# Altium schematic sheet zigbee开发板.SchDoc
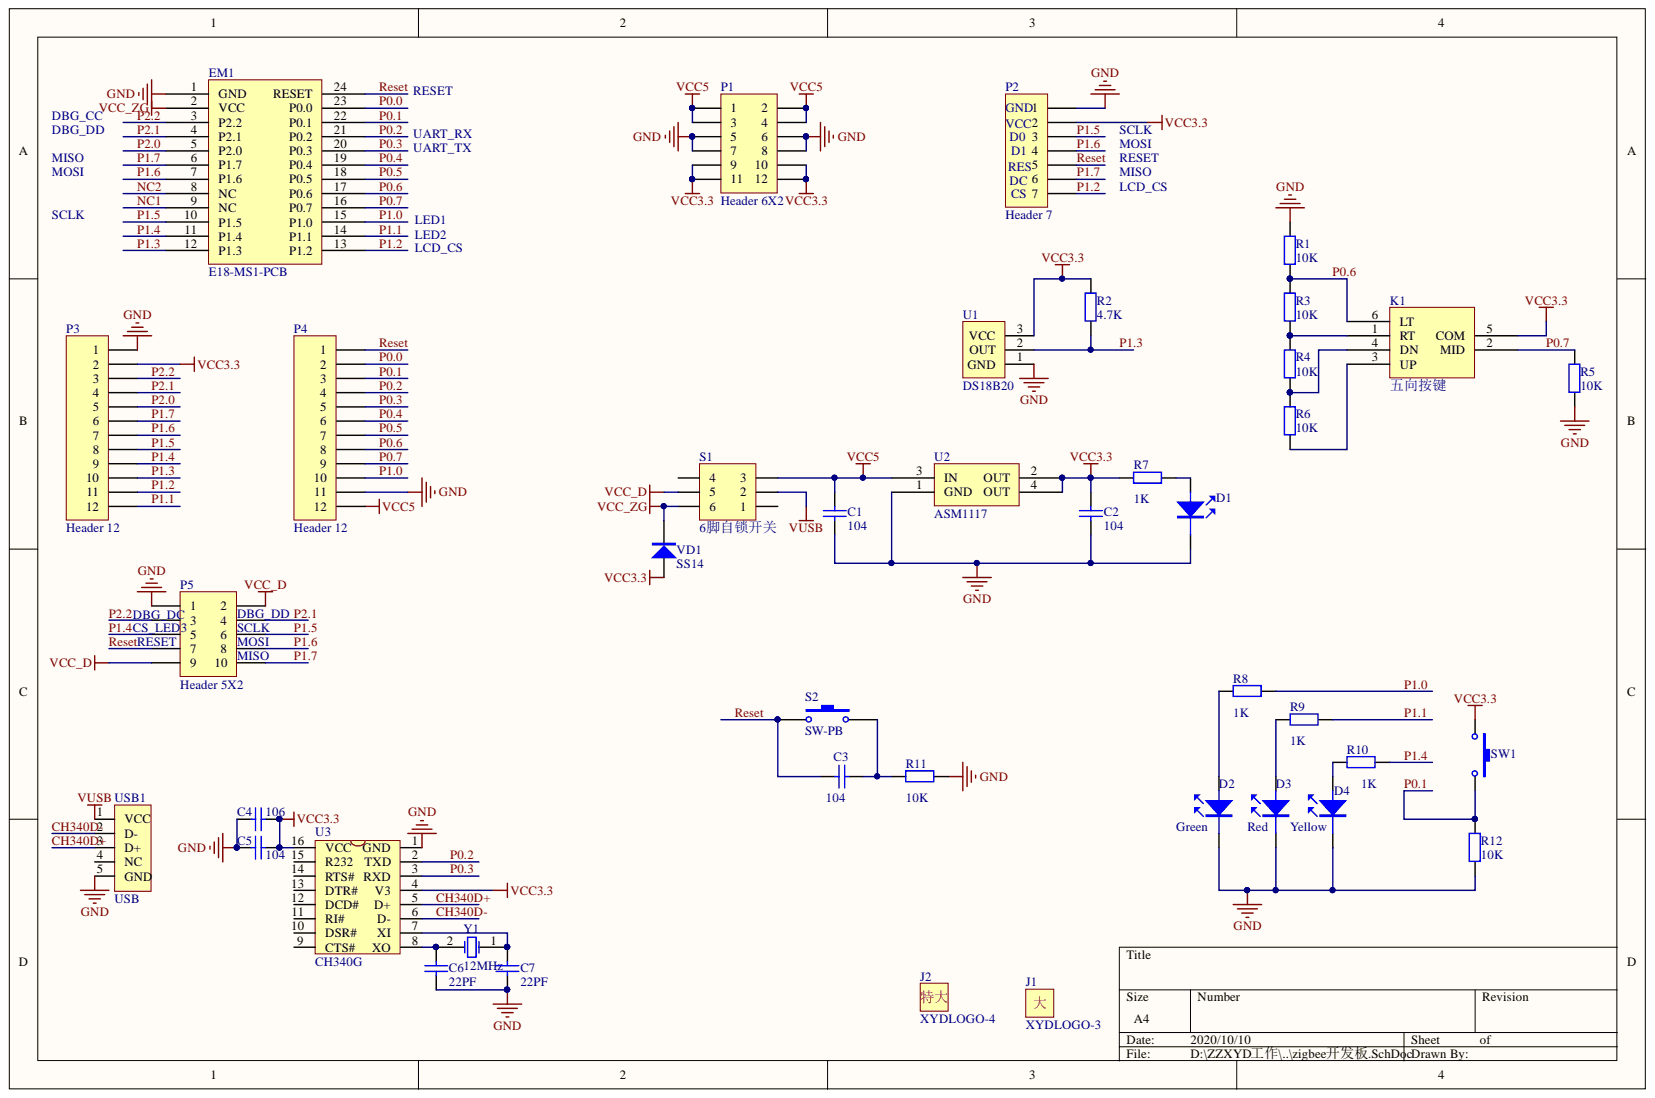
[diagram p0: zigbee开发板.SchDoc]

## Parts
C1  value 104  [C0603]  (hidden comment: Cap)
C2  value 104  [C0603]  (hidden comment: Cap)
C3  value 104  [C0603]  (hidden comment: Cap)
C4  value 106  [C0603]  (hidden comment: Cap)
C5  value 104  [C0603]  (hidden comment: Cap)
C6  value 22PF  [C0603]  (hidden comment: Cap)
C7  value 22PF  [C0603]  (hidden comment: Cap)
D1  Diode  [LED0805R]  (value not drawn)
D2  Diode  [LED0805R]  (value not drawn)
D3  Diode  [LED0805R]  (value not drawn)
D4  Diode  [LED0805R]  (value not drawn)
EM1  E18-MS1-PCB  [PE18-MS1-PCB]
J1  XYDLOGO-3  [XYDLOGO_3]
J2  XYDLOGO-4  [XYDLOGO_4]
K1  五向按键  [五向按键7*7]
P1  Header 6X2  [HDR2X6]
P2  Header 7  [HDR1X7]
P3  Header 12  [HDR2X6]
P4  Header 12  [HDR2X6]
P5  Header 5X2  [DEBUG]
R1  value 10K  [R0603]  (hidden comment: Res2)
R2  value 4.7K  [R0603]  (hidden comment: Res2)
R3  value 10K  [R0603]  (hidden comment: Res2)
R4  value 10K  [R0603]  (hidden comment: Res2)
R5  value 10K  [R0603]  (hidden comment: Res2)
R6  value 10K  [R0603]  (hidden comment: Res2)
R7  value 1K  [R0603]  (hidden comment: Res2)
R8  value 1K  [R0603]  (hidden comment: Res2)
R9  value 1K  [R0603]  (hidden comment: Res2)
R10  value 1K  [R0603]  (hidden comment: Res2)
R11  value 10K  [R0603]  (hidden comment: Res2)
R12  value 10K  [R0603]  (hidden comment: Res2)
S1  6脚自锁开关  [六脚自锁开关]
S2  SW-PB  [SW_PB2_B1]
SW1  SW-PB_1  [SW_PB2_B1]  (value not drawn)
U1  DS18B20  [DS18B20]
U2  ASM1117  [SOT-223]
U3  CH340G  [SOP16]
USB1  USB  [MINIUSB]
VD1  SS14  [SMA]
Y1  12MHz  [49S-DIP]

## Nets
GND: C1.1 C2.1 C4.1 C5.1 C6.1 C7.1 D1.2 D2.2 D3.2 D4.2 EM1.1(GND) P1.5 P1.6 P1.7 P1.8 P2.1 P3.1 P4.11 P5.1 R1.2 R5.1 R11.2 R12.1 U1.1 U2.1(GND) U3.1(GND) USB1.5(GND)
VCC3.3: C2.2 C4.2 C5.2 K1.5(COM) P1.9 P1.10 P1.11 P1.12 P2.2(VCC_2) P3.2 R2.2 R7.1 SW1.1 U1.3 U2.2(OUT) U2.4(OUT) U3.4(V3) U3.16(VCC) VD1.1
VCC5: C1.2 P1.1 P1.2 P1.3 P1.4 P4.12 S1.3 U2.3(IN)
VCC_D: P5.2 P5.9 S1.5
VCC_ZG: EM1.2(VCC) S1.6 VD1.2
VUSB: S1.2 USB1.1(VCC)
CH340D+: U3.5(D+) USB1.3(D+)
CH340D-: U3.6(D-) USB1.2(D-)
NC1: EM1.9(NC)
NC2: EM1.8(NC)
P0.0: EM1.23(P0.0) P4.2
P0.1: EM1.22(P0.1) P4.3 R12.2 SW1.2
P0.2: EM1.21(P0.2) P4.4 U3.2(TXD)
P0.3: EM1.20(P0.3) P4.5 U3.3(RXD)
P0.4: EM1.19(P0.4) P4.6
P0.5: EM1.18(P0.5) P4.7
P0.6: EM1.17(P0.6) K1.6(LT) P4.8 R1.1 R3.2
P0.7: EM1.16(P0.7) K1.2(MID) P4.9 R5.2
P1.0: EM1.15(P1.0) P4.10 R8.2
P1.1: EM1.14(P1.1) P3.12 R9.2
P1.2: EM1.13(P1.2) P2.7(CS) P3.11
P1.3: EM1.12(P1.3) P3.10 R2.1 U1.2
P1.4: EM1.11(P1.4) P3.9 P5.5 R10.2
P1.5: EM1.10(P1.5) P2.3 P3.8 P5.6
P1.6: EM1.7(P1.6) P2.4 P3.7 P5.8
P1.7: EM1.6(P1.7) P2.6(DC_6) P3.6 P5.10
P2.0: EM1.5(P2.0) P3.5
P2.1: EM1.4(P2.1) P3.4 P5.4
P2.2: EM1.3(P2.2) P3.3 P5.3
Reset: C3.1 EM1.24(RESET) P2.5(RES_5) P4.1 P5.7 S2.1
NetC3_2: C3.2 R11.1 S2.2
NetC6_2: C6.2 U3.8(XO) Y1.2
NetC7_2: C7.2 U3.7(XI) Y1.1
NetD1_1: D1.1 R7.2
NetD2_1: D2.1 R8.1
NetD3_1: D3.1 R9.1
NetD4_1: D4.1 R10.1
NetK1_1: K1.1(RT) R3.1 R4.2
NetK1_3: K1.3(UP) R6.1
NetK1_4: K1.4(DN) R4.1 R6.2

Not connected: S1 1 4; U3 9(CTS#) 10(DSR#) 11(RI#) 12(DCD#) 13(DTR#) 14(RTS#) 15(R232); USB1 4(NC)

## Library data of the parts
C1-C7 = Cap: Capacitor; Component Kind: Standard; LatestRevisionDate: 17-Jul-2002; LatestRevisionNote: Re-released for DXP Platform.; Library Name: Miscellaneous Devices.IntLib; PackageDescription: Radial Cap, Thru-Hole; 2 Leads; 0.3 in Pin Spacing; PackageReference: RAD-0.3; Published: 8-Jun-2000; Signal Integrity: Cap; Simulation: CAP; Publisher: Altium Limited
D1-D4 = ZT_LED: Parameter: BT322034; Component Kind: Standard; Library Name: 51最小系统.IntLib
EM1 = E18-MS1PA1-PCB: zegbee模块，带功放; Component Kind: Standard; Library Name: Basic.SchLib
P1 = Header 6X2: Header, 6-Pin, Dual row; Component Kind: Standard; LatestRevisionDate: 17-Jul-2002; LatestRevisionNote: Re-released for DXP Platform.; Library Name: Miscellaneous Connectors.IntLib; Signal Integrity: Connector; Publisher: Altium Limited
P2 = Header 7: Header, 7-Pin; Component Kind: Standard; LatestRevisionDate: 17-Jul-2002; LatestRevisionNote: Re-released for DXP Platform.; Library Name: Miscellaneous Connectors.IntLib; Signal Integrity: Connector; Publisher: Altium Limited
P3 P4 = Header 12: Header, 12-Pin; Component Kind: Standard; LatestRevisionDate: 17-Jul-2002; LatestRevisionNote: Re-released for DXP Platform.; Library Name: Miscellaneous Connectors.IntLib; Signal Integrity: Connector; Publisher: Altium Limited
P5 = Header 5X2: Header, 5-Pin, Dual row; Component Kind: Standard; LatestRevisionDate: 17-Jul-2002; LatestRevisionNote: Re-released for DXP Platform.; Library Name: Miscellaneous Connectors.IntLib; Signal Integrity: Connector; Publisher: Altium Limited
R1-R12 = Res2: Resistor; Component Kind: Standard; LatestRevisionDate: 17-Jul-2002; LatestRevisionNote: Re-released for DXP Platform.; Library Name: Miscellaneous Devices.IntLib; PackageDescription: Axial Device, Thru-Hole; 2 Leads; 0.4 in Pin Spacing; PackageReference: AXIAL-0.4; Published: 8-Jun-2000; Signal Integrity: Res; Simulation: RESISTOR; Publisher: Altium Limited
S2 = SW-PB: 微动开关; Component Kind: Standard; LatestRevisionDate: 17-Jul-2002; LatestRevisionNote: Re-released for DXP Platform.; PackageReference: SPST-2; Published: 24-Mar-1999; Library Name: Fszhang.IntLib; Publisher: Altium Limited
SW1 = SW-PB_1: Switch; Component Kind: Standard; LatestRevisionDate: 17-Jul-2002; LatestRevisionNote: Re-released for DXP Platform.; PackageDescription: Switch; 2 Leads; PackageReference: SPST-2; Published: 24-Mar-1999; Library Name: 51最小系统.IntLib; Publisher: Altium Limited
U2 = ASM1117: 3.3V稳压芯片; Component Kind: Standard; Library Name: fszhang.SchLib
U3 = CH340G: USB2UART; Component Kind: Standard; Library Name: WarShip V3 STM32103封装库.IntLib
USB1 = USB5: Component Kind: Standard; Library Name: WarShip V3 STM32103封装库.IntLib
VD1 = SS14: 肖特基二极管; 属性: 40V/1A; 版权归属: 源创客; BOM名称: 肖特基二极管; Component Kind: Standard; Library Name: 二极管-整流桥.SchLib; 学习资源: www.ooocke.com
Y1 = XTAL: Crystal Oscillator; Component Kind: Standard; LatestRevisionDate: 17-Jul-2002; LatestRevisionNote: Re-released for DXP Platform.; Library Name: WarShip V3 STM32103封装库.IntLib; Published: 8-Jun-2000; Simulation: XTAL; Publisher: Altium Limited
J1 = XYDLOGO-3: Component Kind: Standard; Library Name: 常用器件原理图库.SCHLIB
J2 = XYDLOGO-4: Component Kind: Standard; Library Name: 常用器件原理图库.SCHLIB
K1 = 五向按键: Component Kind: Standard; Library Name: ZigBee开发板.SCHLIB
S1 = 6脚自锁开关: Component Kind: Standard; Library Name: Schlib1.SchLib
U1 = DS18B20: Component Kind: Standard; Library Name: 常用器件库.IntLib
